# Altium schematic sheet main.SchDoc
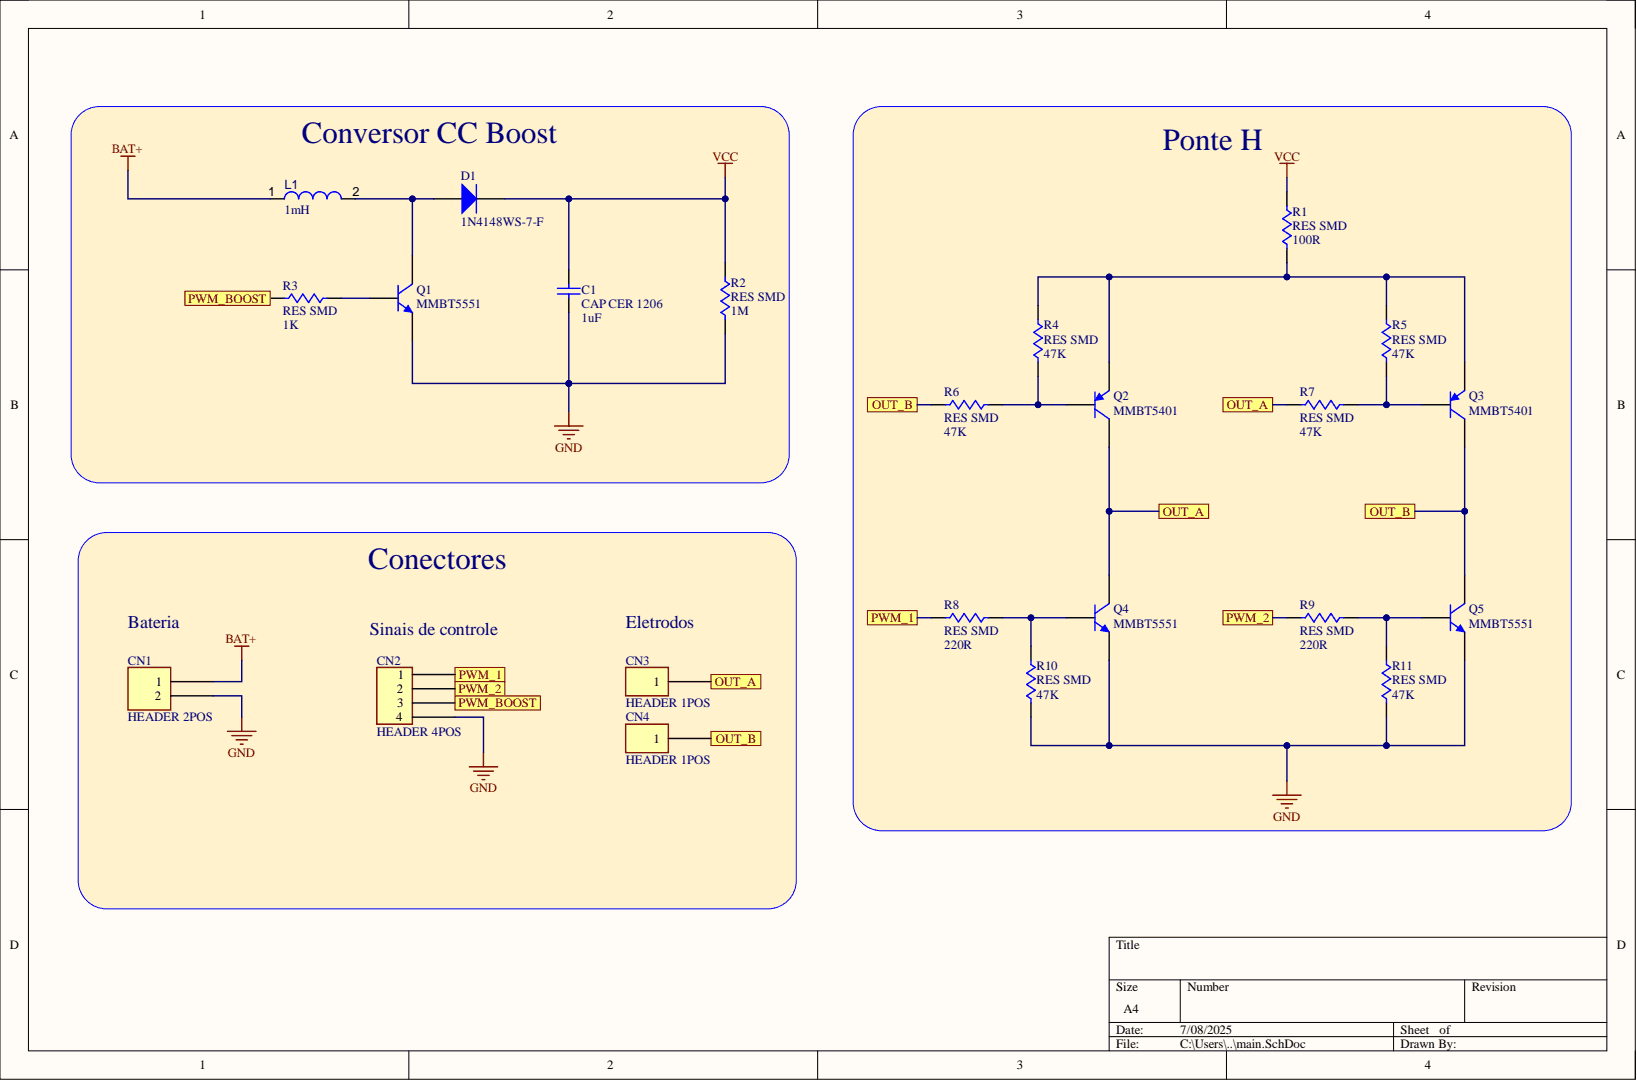
[diagram p0: main.SchDoc]

## Parts
C1  CAP CER 1206  Valor: 1uF  [CAP CER 1206]
CN1  HEADER 2POS  [HEADER-MACHO-2POS]
CN2  HEADER 4POS  [HEADER-MACHO-4POS]
CN3  HEADER 1POS  [HEADER-MACHO-1POS]
CN4  HEADER 1POS  [HEADER-MACHO-1POS]
D1  1N4148WS-7-F  [DIOD-SOD323-2-A_V]
L1  value 1mH  [TDK-VLCF5028-2_V]  (hidden comment: VLCF5028T-2R7N1R8-2)
Q1  MMBT5551  [SOT-23 / Proto]
Q2  MMBT5401  [DIOD-SOT23-3_V]
Q3  MMBT5401  [DIOD-SOT23-3_V]
Q4  MMBT5551  [SOT-23 / Proto]
Q5  MMBT5551  [SOT-23 / Proto]
R1  RES SMD  Valor: 100R  [SMD 0603]
R2  RES SMD  Valor: 1M  [SMD 0603]
R3  RES SMD  Valor: 1K  [SMD 0603]
R4  RES SMD  Valor: 47K  [SMD 0603]
R5  RES SMD  Valor: 47K  [SMD 0603]
R6  RES SMD  Valor: 47K  [SMD 0603]
R7  RES SMD  Valor: 47K  [SMD 0603]
R8  RES SMD  Valor: 220R  [SMD 0603]
R9  RES SMD  Valor: 220R  [SMD 0603]
R10  RES SMD  Valor: 47K  [SMD 0603]
R11  RES SMD  Valor: 47K  [SMD 0603]

## Ports
OUT_A, OUT_B, PWM_1, PWM_2, PWM_BOOST

## Nets
BAT+: CN1.1 L1.1
GND: C1.1 CN1.2 CN2.4 Q1.2 Q4.2 Q5.2 R2.1 R10.1 R11.1
VCC: C1.2 D1.2 R1.2 R2.2
OUT_A: CN3.1 Q2.3 Q4.3 R7.1 ; port OUT_A
OUT_B: CN4.1 Q3.3 Q5.3 R6.1 ; port OUT_B
PWM_1: CN2.1 R8.1 ; port PWM_1
PWM_2: CN2.2 R9.1 ; port PWM_2
PWM_BOOST: CN2.3 R3.1 ; port PWM_BOOST
NetD1_1: D1.1 L1.2 Q1.3
NetQ1_1: Q1.1 R3.2
NetQ2_1: Q2.1 R4.1 R6.2
NetQ2_2: Q2.2 Q3.2 R1.1 R4.2 R5.2
NetQ3_1: Q3.1 R5.1 R7.2
NetQ4_1: Q4.1 R8.2 R10.2
NetQ5_1: Q5.1 R9.2 R11.2

## Library data of the parts
C1 = CAP CER 1206: CAPACITOR DE CERAMICA 1206 SMD; Component Kind: Standard; ComponentLink1Description: New link; Item: CAP CER 1206; Library Name: IntLib_CAP_SMD.IntLib
CN1 = HEADER-MACHO-2POS: Conector barra de pinos Furo passante, 2 posições (2,54mm); Part Number: 61300211121; Endereço Gaveteiro: ***; Item: HEADER MACHO 2POS; Component Kind: Standard; ComponentLink1Description: HEADER MACHO 2POS; ComponentLink1URL: https://www.digikey.com/en/products/detail/w%C3%BCrth-elektronik/61300211121/4846823; Library Name: IntLib_Conectores.IntLib
CN2 = HEADER-MACHO-4POS: Conector barra de pinos Furo passante, 4 posições (2,54mm); Part Number: 61300411121; Endereço Gaveteiro: ***; Item: HEADER MACHO 4POS; Component Kind: Standard; ComponentLink1Description: HEADER MACHO 4POS; ComponentLink1URL: https://www.digikey.com/en/products/detail/w%C3%BCrth-elektronik/61300411121/4846827; Library Name: IntLib_Conectores.IntLib
CN3 CN4 = HEADER-MACHO-1POS: Conector barra de pinos Furo passante, 1 posições; Part Number: 61300211121; Endereço Gaveteiro: ***; Item: HEADER MACHO 2POS; Component Kind: Standard; ComponentLink1Description: HEADER MACHO 2POS; ComponentLink1URL: https://www.digikey.com/en/products/detail/w%C3%BCrth-elektronik/61300211121/4846823; Library Name: IntLib_Conectores.IntLib
D1 = CMP-004-00002-2: Fast Switching Diode, 75 V, 0.3 A, -65 to 150 degC, 2-Pin SOD323, RoHS, Tape and Reel; Manufacturer: Diodes Inc; Elements: 1; Forward Current: 300mA; Forward Voltage: 1.25V; Max Surge Current: 2A; Peak Reverse Current: 1uA; Recovery Time: 4ns; Case/Package: SOD; Component Kind: Standard; Datasheet URL: http://www.diodes.com/datasheets/ds30097.pdf; Datasheet Version: Rev. 25-2, 03/2016; Manufacturer URL: http://www.diodes.com/; Max Operating Temperature: 150°C; Min Operating Temperature: -65°C; Mounting Technology: SM; Package Description: 2-Pin Small Outline Diode, Body 1.7 x 1.3 mm; Package Quantity: 3000; Package Reference: SOD323-2; Packaging: TapeandReel; Pins: 2; RoHS Compliant: True; Library Name: Edino
L1 = CMP-006-00017-6: Inductor for Power Circuits, 2.7 uH, +/- 30%,100 KHz, 1.82 A, -40 to 105 degC, 2-Pin SMD, RoHS, Reel; Manufacturer: TDK; Core Material: Ferrite; Current Rating: 1.82A; Resistance: 0.033Ohm; Shielding: Shielded; Case/Package: 5028; Component Kind: Standard; Datasheet URL: https://product.tdk.com/info/en/catalog/datasheets/inductor_commercial_power_vlcf5028-2_en.pdf; Datasheet Version: Apr-16; Inductance Tolerance: 30%; Max Operating Temperature: 105°C; Min Operating Temperature: -40°C; Mounting Technology: SM; Package Description: 2-Pin Surface Mount Device, Body 5.2 x 5.3 mm; Package Quantity: 500; Package Reference: VLCF5028-2; Packaging: TapeandReel; RoHS Compliant: True; Saturation Current: 1.82A; Tolerance: 30%; Library Name: Edino
Q1 Q4 Q5 = BC847BLT1G: Transistor NPN 45V 0.1A; Part Number: BC847; Endereço Gaveteiro: ***; Item: Transistor NPN 45V 0.1A BC847; Component Kind: Standard; ComponentLink1Description: BC847; ComponentLink1URL: digikey.com/en/products/detail/onsemi/BC847BLT1G/918344; Library Name: IntLib_transistores.IntLib
Q2 Q3 = CMP-00002-1: Small Signal Bipolar Transistor, 0.5A I(C), 300V V(BR)CEO, 1-Element, PNP, Silicon; Additional Feature: HIGH RELIABILITY; DC Current Gain-Min (hFE): 25; Frequency: 50MHz; Max Collector Current: 500mA; Number of Elements: 1; Package Body Material: Plastic; Power Dissipation-Max (Abs): 300mW; Qualification Status: Not Qualified; Time @ Peak Reflow Temperature-Max (s): 40s; Transistor Application: SWITCHING; Collector-emitter Voltage-Max: 300V; Component Kind: Standard; JESD-30 Code: R-PDSO-G3; JESD-609 Code: e3; Max Operating Temperature: 150°C; Moisture Sensitivity Level: 1; Package Shape: Rectangular; Package Style: SMALL OUTLINEMeter; Peak Reflow Temperature (Cel): 260°C; Pins: 3; Polarity/Channel Type: PNP; Reach SVHC Compliant: Yes; RoHS Compliant: Yes; Surface Mount: Yes; Terminal Finish: Tin; Terminal Form: Gull Wing; Terminal Position: DUAL; Transistor Element Material: SILICON; Library Name: Edino
R1-R11 = RES SMD: Component Kind: Standard; ComponentLink1Description: Link; Description: RES SMD; Item: RES SMD; Library Name: IntLib_RESISTORES.IntLib
